# Altium schematic sheet Baseband.SchDoc(Baseband)
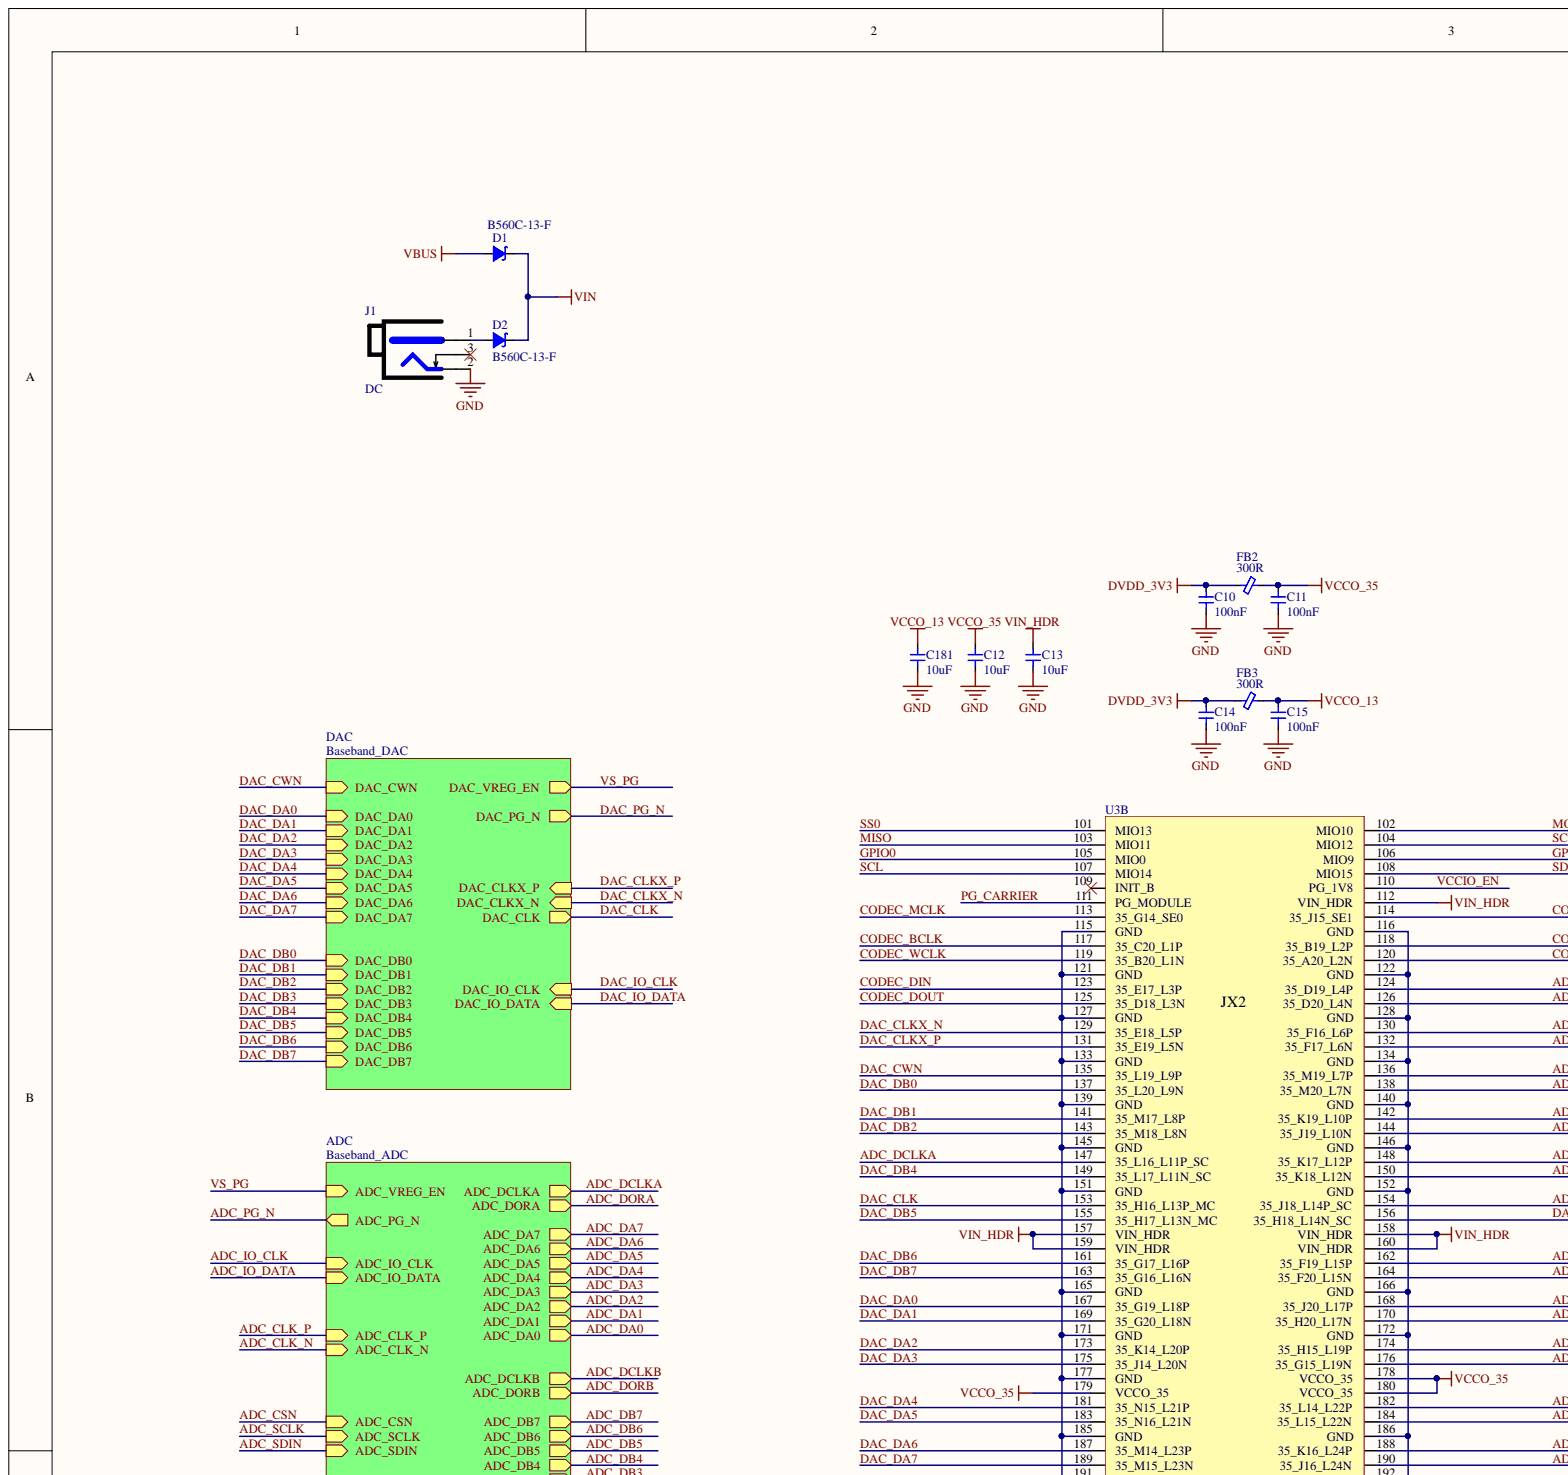
[diagram p0: Baseband.SchDoc, top left]
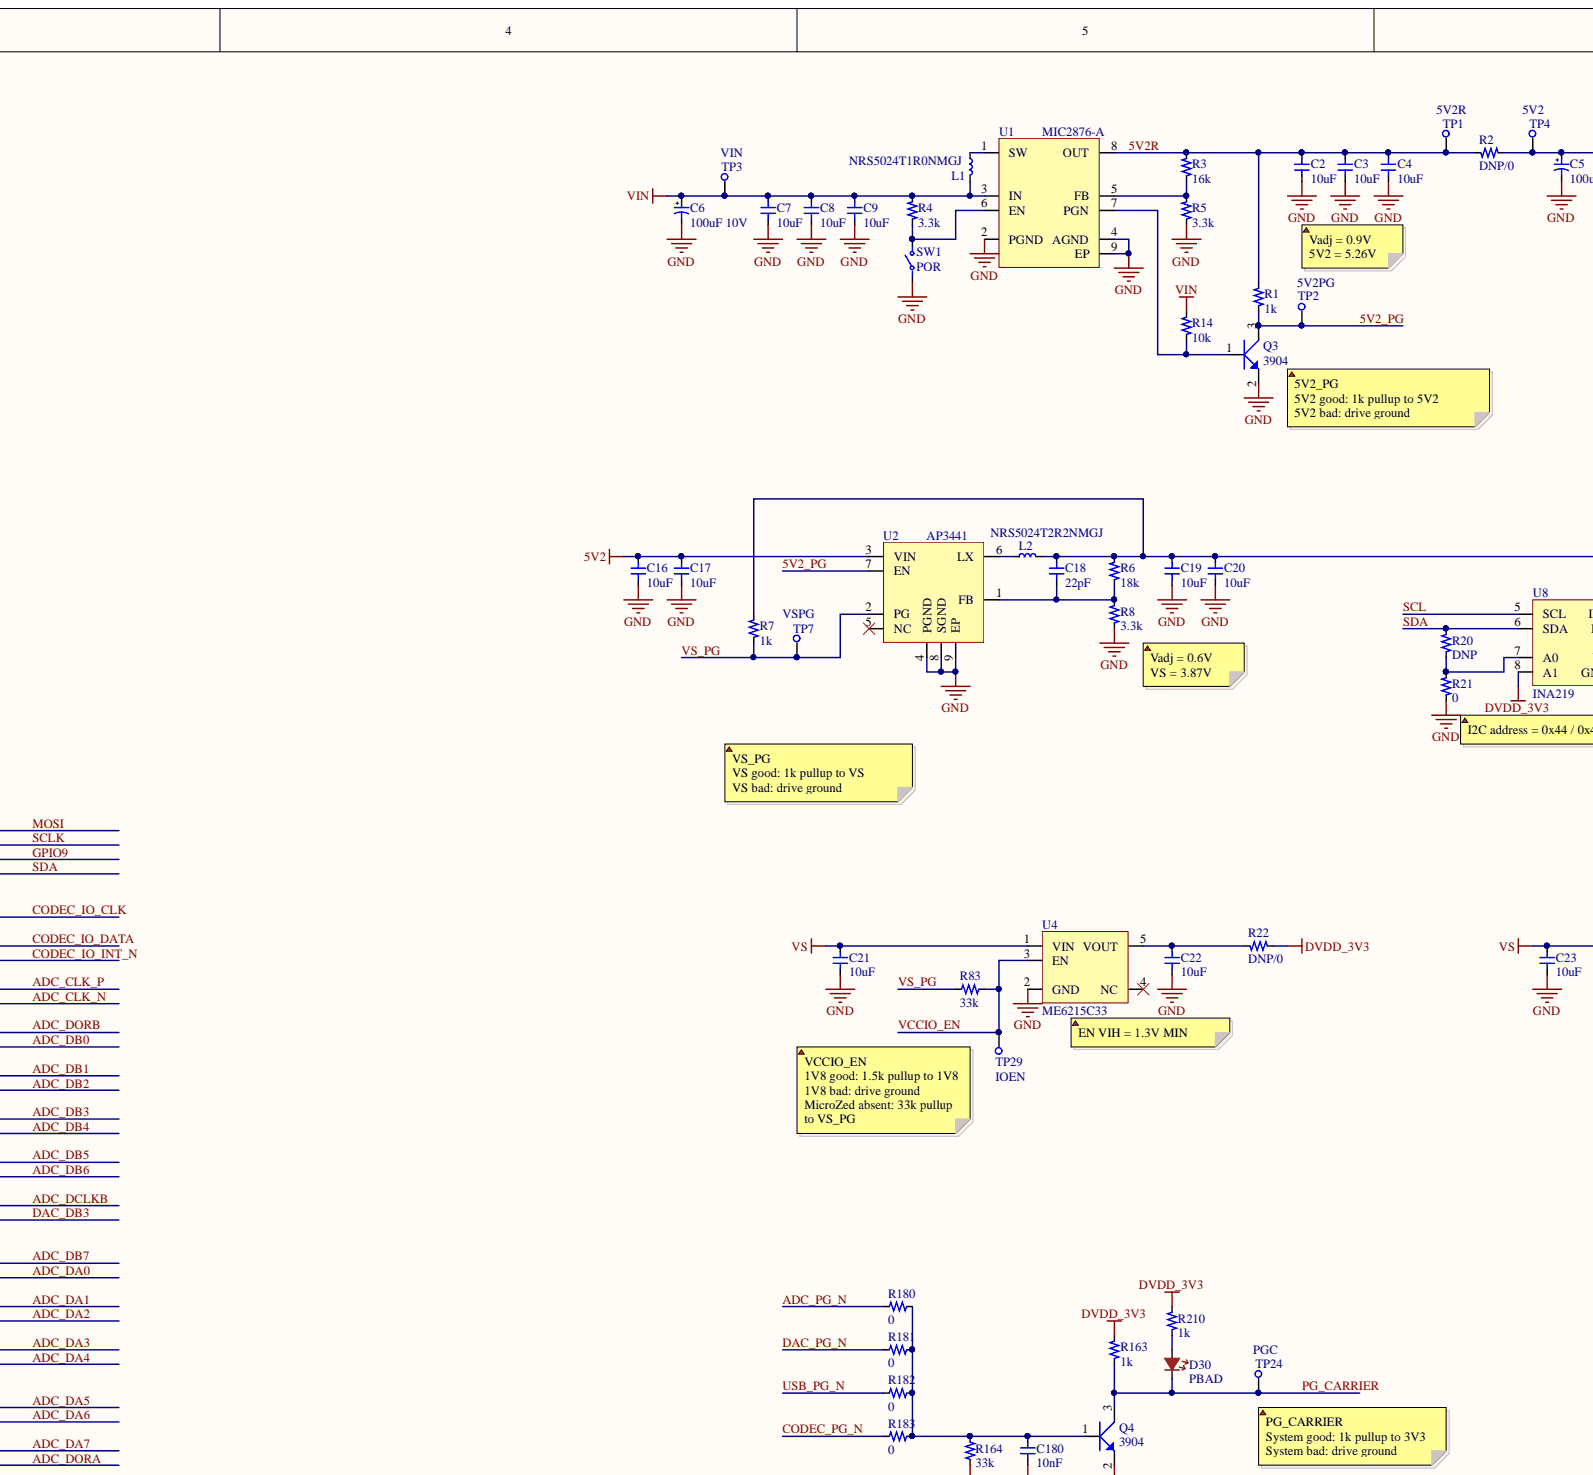
[diagram p1: Baseband.SchDoc, top centre]
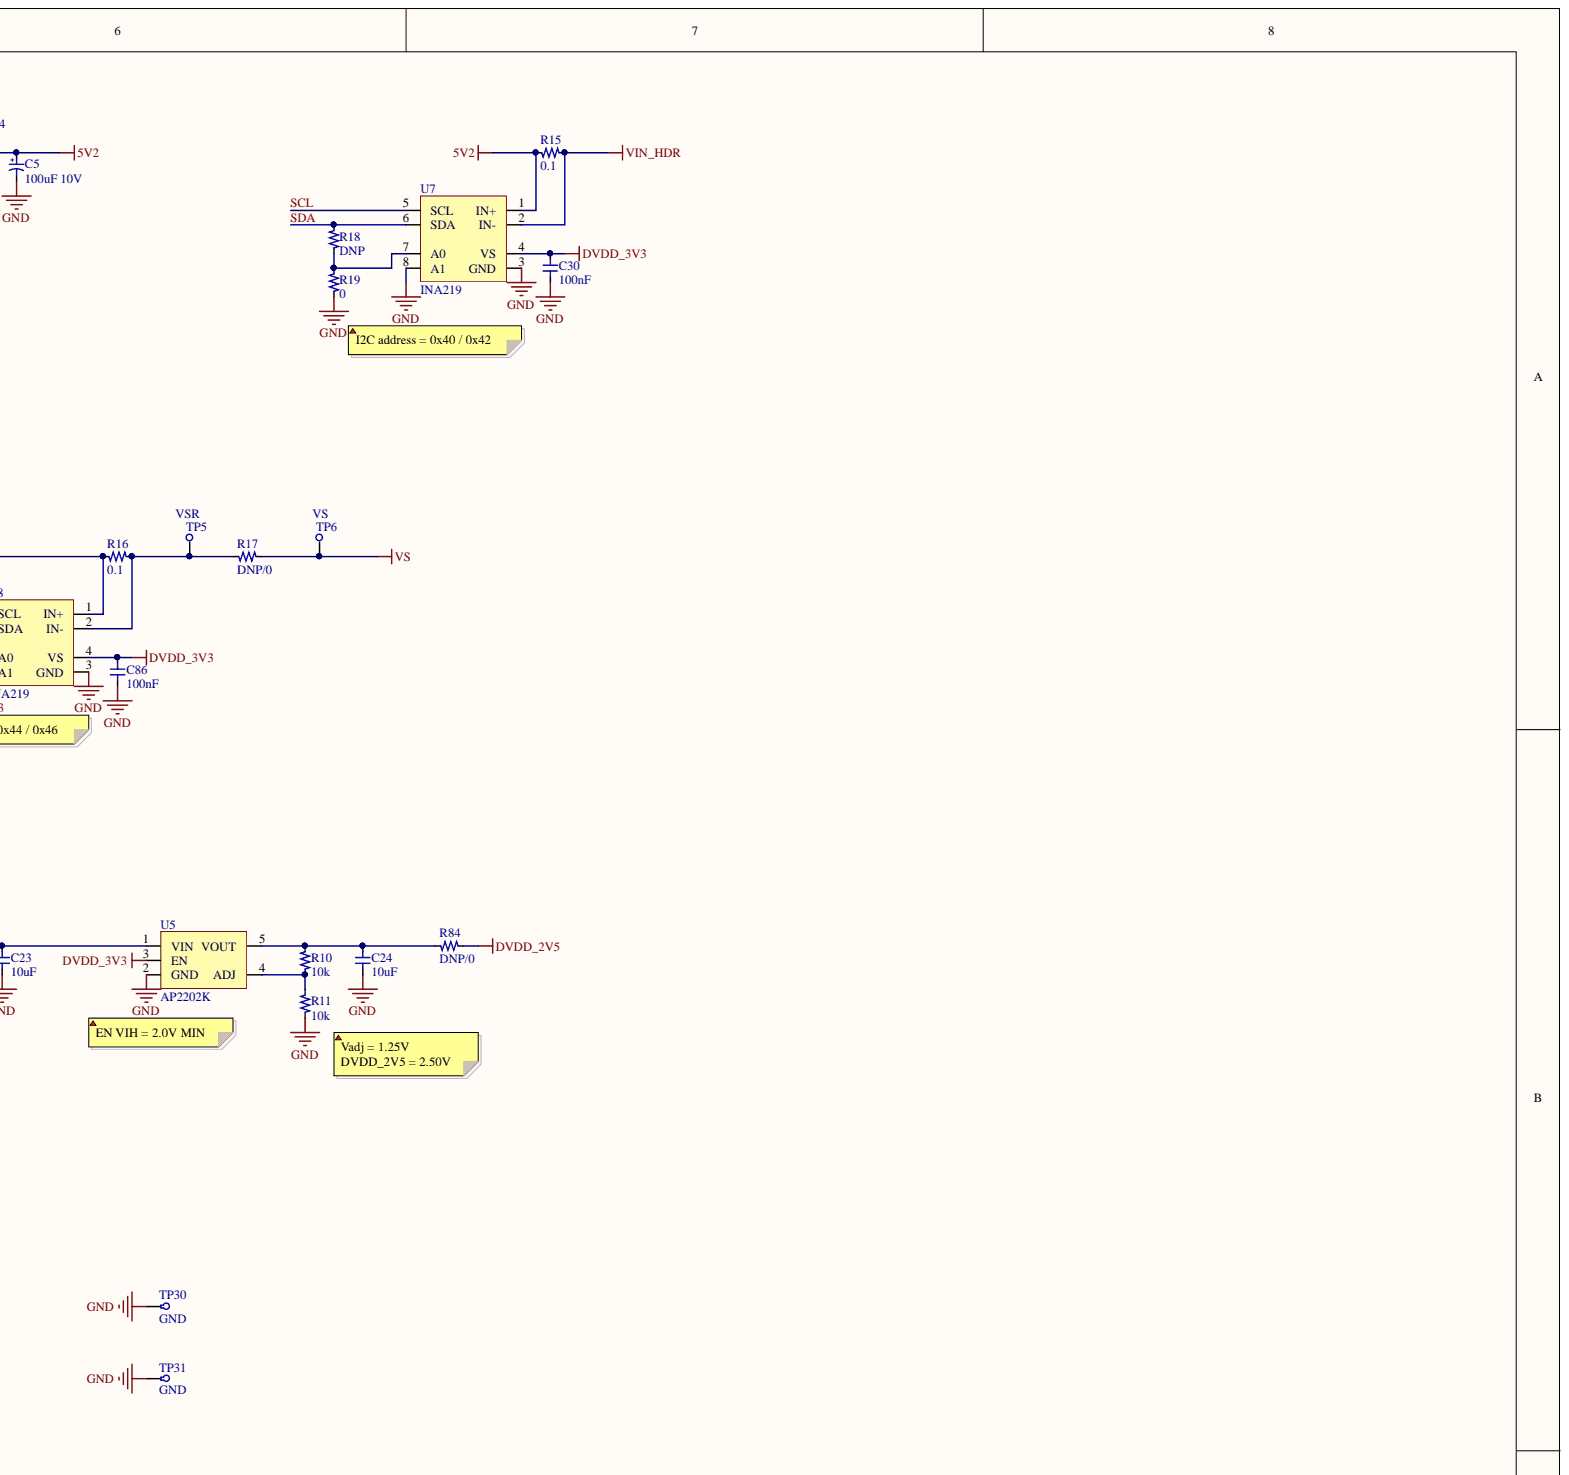
[diagram p2: Baseband.SchDoc, top right]
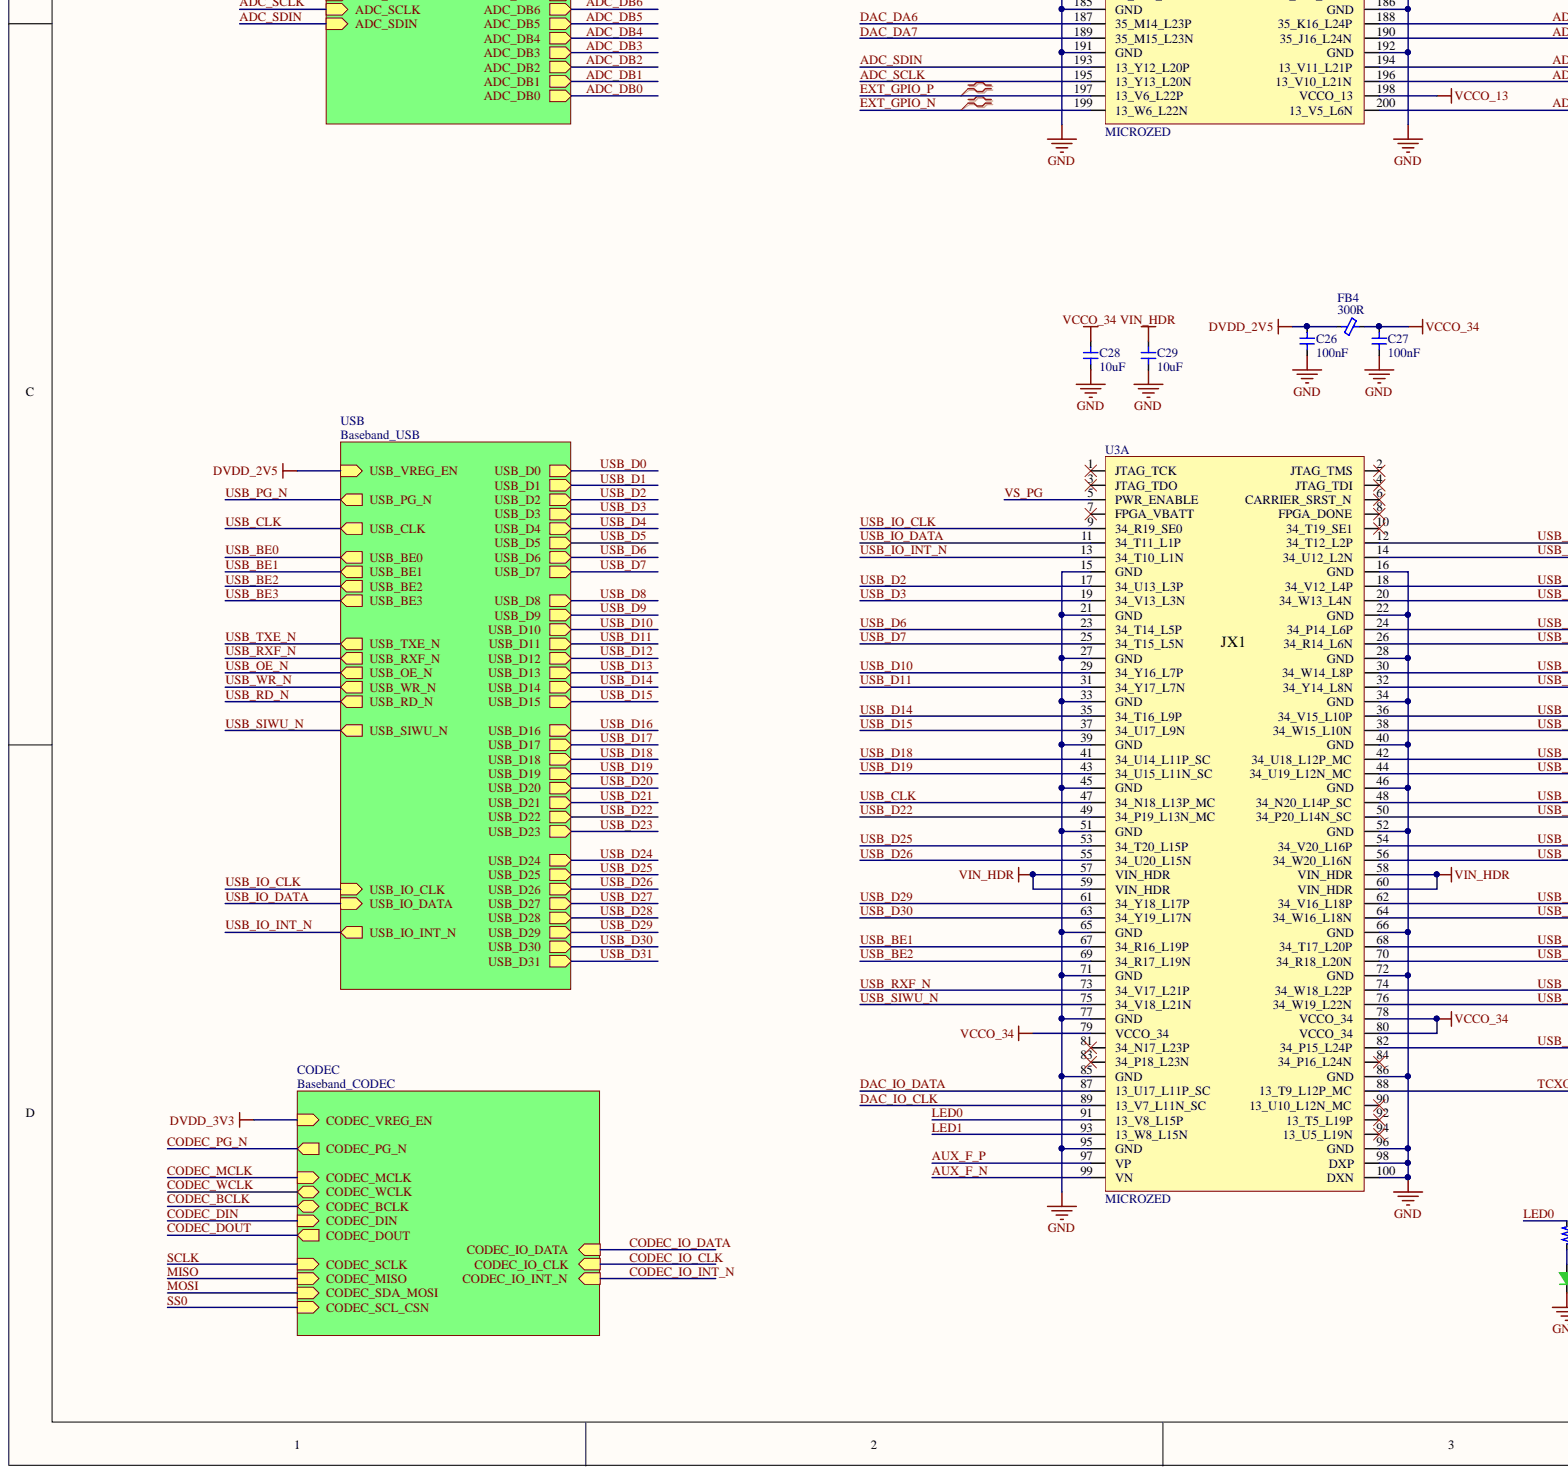
[diagram p3: Baseband.SchDoc, bottom left]
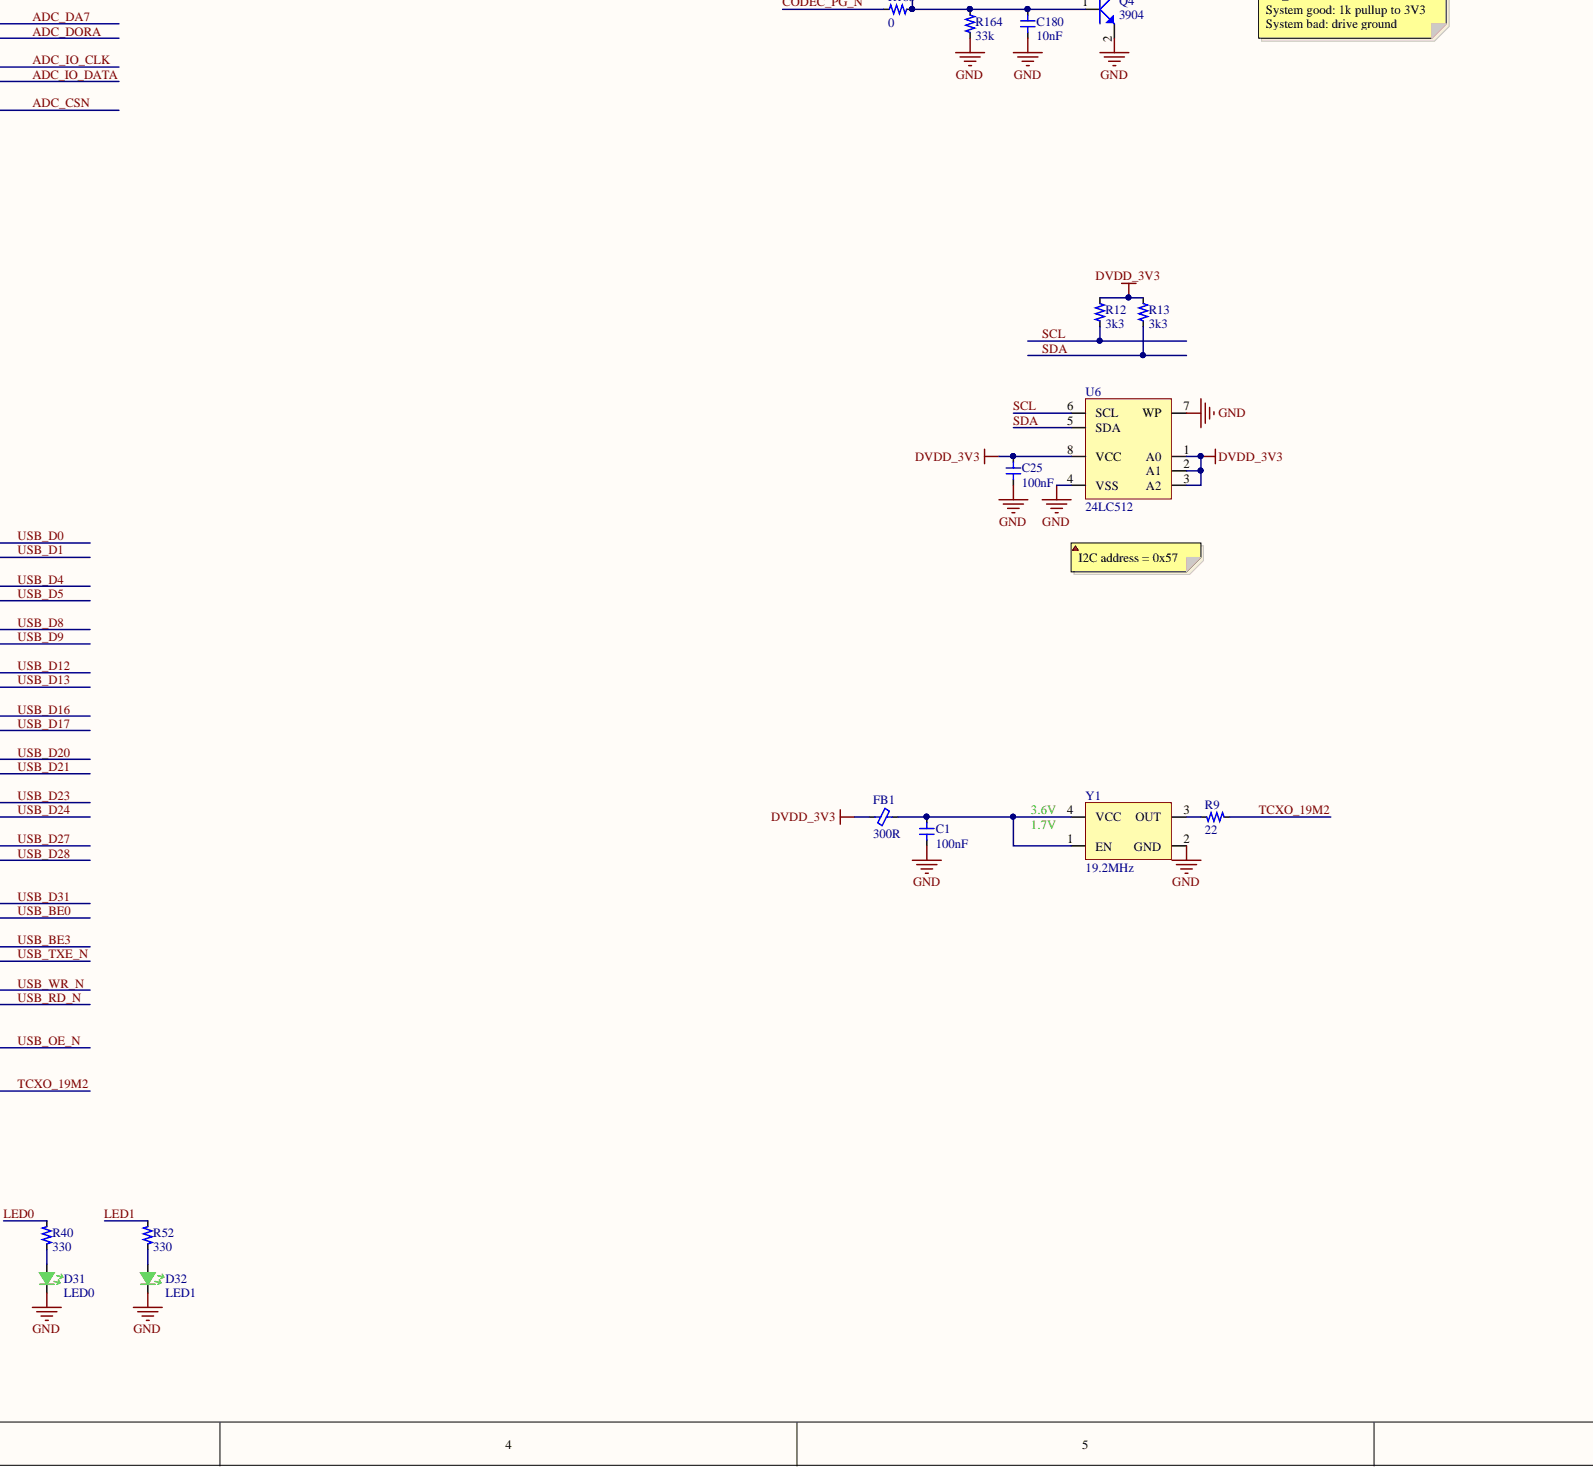
[diagram p4: Baseband.SchDoc, bottom centre]
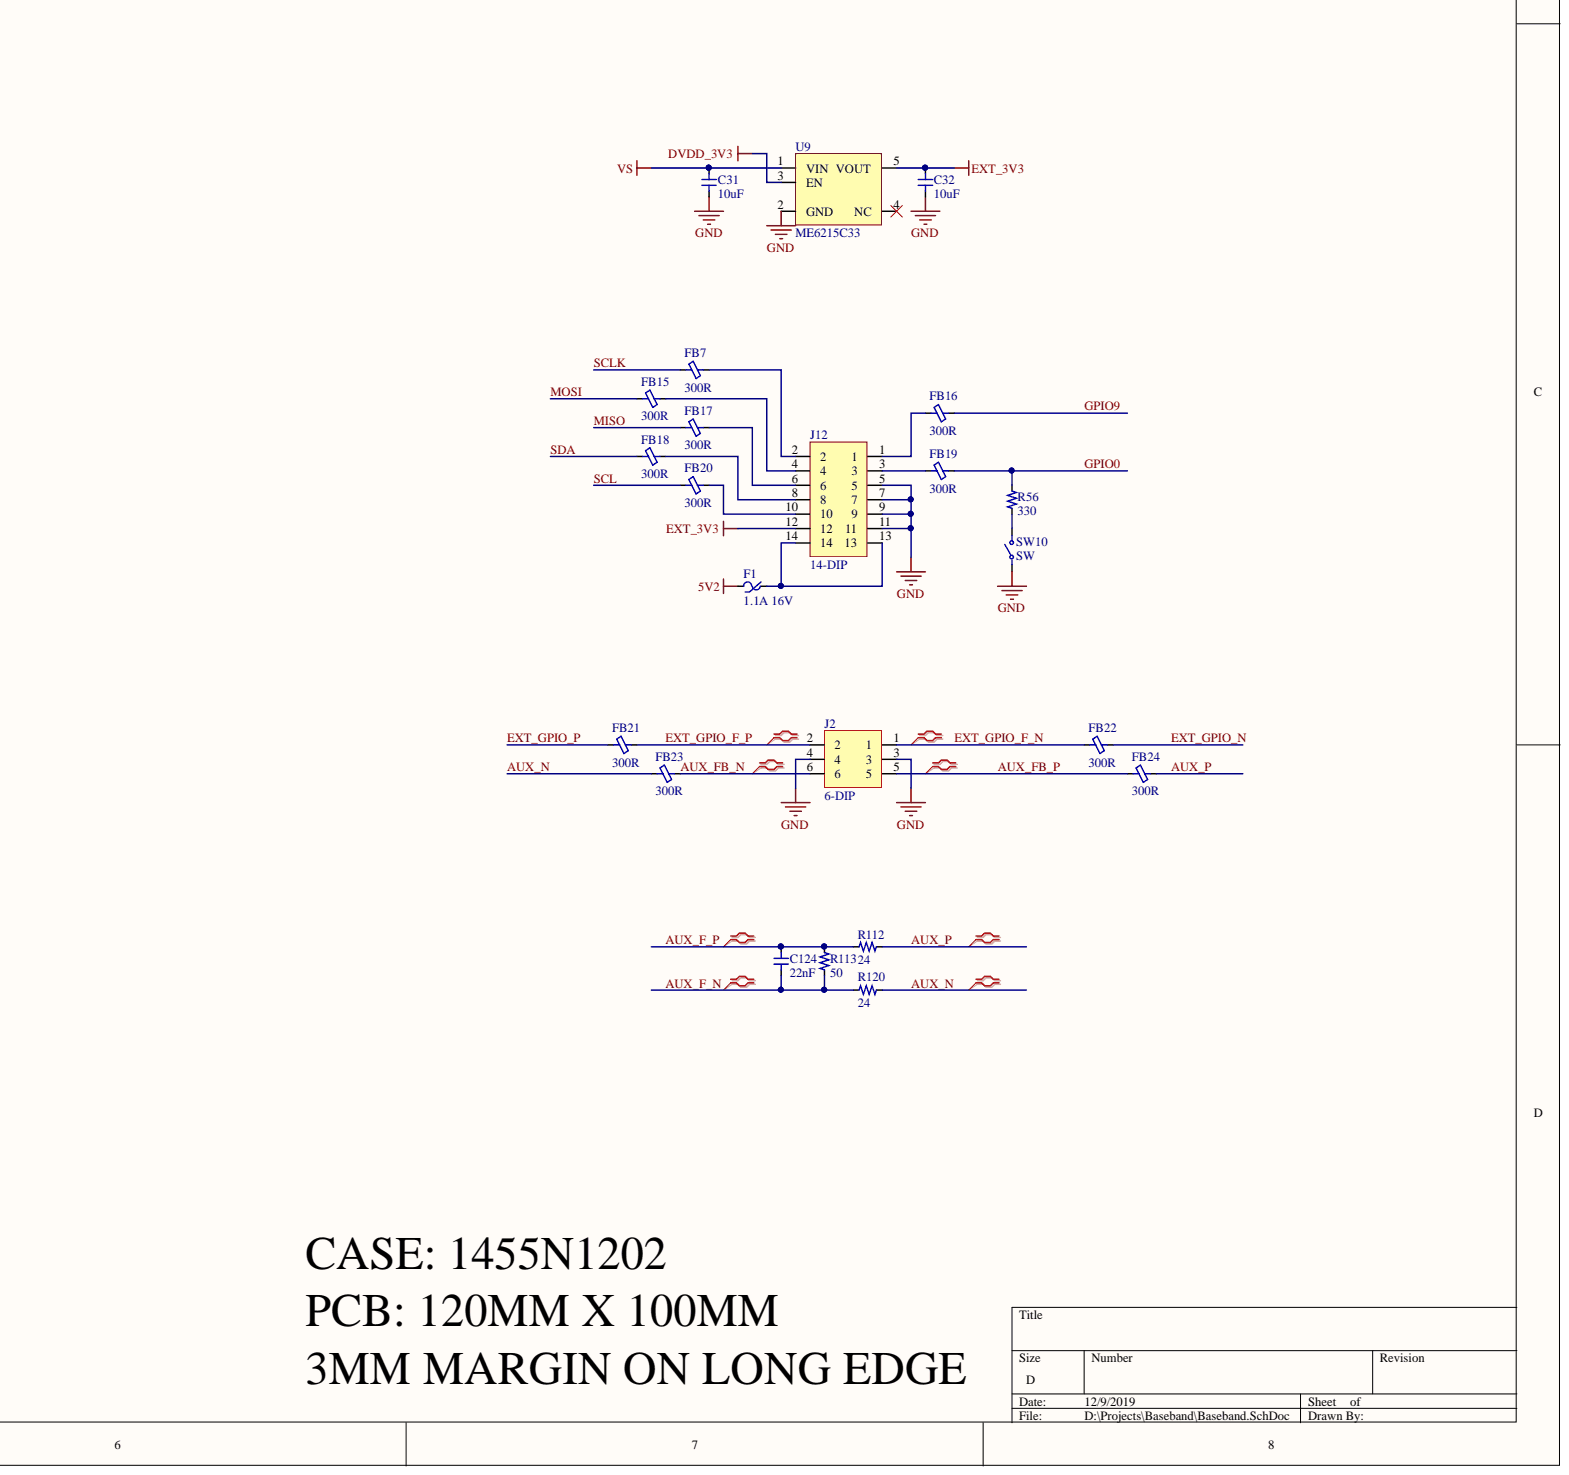
[diagram p5: Baseband.SchDoc, bottom right]

## Parts
C1  100nF  [2-0603C]
C2  10uF  [2-1206C]
C3  10uF  [2-1206C]
C4  10uF  [2-1206C]
C5  100uF 10V  [2-CAP_AL_5D6H]
C6  100uF 10V  [2-CAP_AL_5D6H]
C7  10uF  [2-1206C]
C8  10uF  [2-1206C]
C9  10uF  [2-1206C]
C10  100nF  [2-0603C]
C11  100nF  [2-0603C]
C12  10uF  [2-1206C]
C13  10uF  [2-1206C]
C14  100nF  [2-0603C]
C15  100nF  [2-0603C]
C16  10uF  [2-1206C]
C17  10uF  [2-1206C]
C18  22pF  [2-0603C]
C19  10uF  [2-1206C]
C20  10uF  [2-1206C]
C21  10uF  [2-1206C]
C22  10uF  [2-1206C]
C23  10uF  [2-1206C]
C24  10uF  [2-1206C]
C25  100nF  [2-0603C]
C26  100nF  [2-0603C]
C27  100nF  [2-0603C]
C28  10uF  [2-1206C]
C29  10uF  [2-1206C]
C30  100nF  [2-0603C]
C31  10uF  [2-1206C]
C32  10uF  [2-1206C]
C86  100nF  [2-0603C]
C124  22nF  [2-0603C]
C180  10nF  [2-0603C]
C181  10uF  [2-1206C]
D1  B560C-13-F  [2-SMC]
D2  B560C-13-F  [2-SMC]
D30  PBAD  [2-0603_LED_RED]
D31  LED0  [2-0603_LED_GREEN]
D32  LED1  [2-0603_LED_GREEN]
F1  1.1A 16V  [1812L110/16DR]
FB1  300R  [2-0805L]
FB2  300R  [2-0805L]
FB3  300R  [2-0805L]
FB4  300R  [2-0805L]
FB7  300R  [2-0805L]
FB15  300R  [2-0805L]
FB16  300R  [2-0805L]
FB17  300R  [2-0805L]
FB18  300R  [2-0805L]
FB19  300R  [2-0805L]
FB20  300R  [2-0805L]
FB21  300R  [2-0805L]
FB22  300R  [2-0805L]
FB23  300R  [2-0805L]
FB24  300R  [2-0805L]
J1  DC  [3-DC]
J2  6-DIP  [6-DIP_HDR_STR_TH]
J12  14-DIP  [14-DIP_HDR_STR_TH]
L1  NRS5024T1R0NMGJ  [2-5050L]
L2  NRS5024T2R2NMGJ  [2-5050L]
Q3  3904  [3-SOT23]
Q4  3904  [3-SOT23]
R1  1k  [2-0603R]
R2  DNP/0  [2-0603R]
R3  16k  [2-0603R]
R4  3.3k  [2-0603R]
R5  3.3k  [2-0603R]
R6  18k  [2-0603R]
R7  1k  [2-0603R]
R8  3.3k  [2-0603R]
R9  22  [2-0603R]
R10  10k  [2-0603R]
R11  10k  [2-0603R]
R12  3k3  [2-0603R]
R13  3k3  [2-0603R]
R14  10k  [2-0603R]
R15  0.1  [2-0603R]
R16  0.1  [2-0603R]
R17  DNP/0  [2-0603R]
R18  DNP  [2-0603R]
R19  0  [2-0603R]
R20  DNP  [2-0603R]
R21  0  [2-0603R]
R22  DNP/0  [2-0603R]
R40  330  [2-0603R]
R52  330  [2-0603R]
R56  330  [2-0603R]
R83  33k  [2-0603R]
R84  DNP/0  [2-0603R]
R112  24  [2-0603R]
R113  50  [2-0603R]
R120  24  [2-0603R]
R163  1k  [2-0603R]
R164  33k  [2-0603R]
R180  0  [2-0603R]
R181  0  [2-0603R]
R182  0  [2-0603R]
R183  0  [2-0603R]
R210  1k  [2-0603R]
SW1  POR  [2-TACT_6W3D4H]
SW10  SW  [2-TACT_6W3D4H]
TP1  5V2R  [1-TP_1.5MM]
TP2  5V2PG  [1-TP_1.5MM]
TP3  VIN  [1-TP_1.5MM]
TP4  5V2  [1-TP_1.5MM]
TP5  VSR  [1-TP_1.5MM]
TP6  VS  [1-TP_1.5MM]
TP7  VSPG  [1-TP_1.5MM]
TP24  PGC  [1-TP_1.5MM]
TP29  IOEN  [1-TP_1.5MM]
TP30  GND  [1-TPLOOP]
TP31  GND  [1-TPLOOP]
U1  MIC2876-A  [8-DFN_2X2]
U2  AP3441  [8-DFN_2X2]
U3  MICROZED  [MICROZED_2MOUNT]  (parts A,B)
U4  ME6215C33  [5-SOT23]
U5  AP2202K  [5-SOT23]
U6  24LC512  [8-TSSOP]
U7  INA219  [8-SOIC_N]
U8  INA219  [8-SOIC_N]
U9  ME6215C33  [5-SOT23]
Y1  19.2MHz  [4-XTAL_2016]

## Nets
5V2: C5.1 C16.1 C17.1 F1.1 R2.2 R15.2 TP4.1 U2.3(VIN) U7.1(IN+)
DVDD_2V5: C26.1 FB4.2 R84.2
DVDD_3V3: C10.1 C14.1 C25.1 C30.1 C86.1 FB1.2 FB2.2 FB3.2 R12.2 R13.2 R22.2 R163.1 R210.1 U5.3(EN) U6.1(A0) U6.2(A1) U6.3(A2) U6.8(VCC) U7.4(VS) U8.4(VS) U8.8(A1) U9.3(EN)
EXT_3V3: C32.1 J12.12 U9.5(VOUT)
GND: C1.2 C2.2 C3.2 C4.2 C5.2 C6.2 C7.2 C8.2 C9.2 C10.2 C11.2 C12.2 C13.2 C14.2 C15.2 C16.2 C17.2 C19.2 C20.2 C21.2 C22.2 C23.2 C24.2 C25.2 C26.2 C27.2 C28.2 C29.2 C30.2 C31.2 C32.2 C86.2 C180.2 C181.2 D31.2 D32.2 J1.2 J2.3 J2.4 J12.5 J12.7 J12.9 J12.11 Q3.2 Q4.2 R5.2 R8.2 R11.2 R19.1 R21.1 R164.2 SW1.1 SW10.1 TP30.1 TP31.1 U1.2(PGND) U1.4(AGND) U1.9(EP) U2.4(PGND) U2.8(SGND) U2.9(EP) U3.15(GND) U3.16(GND) U3.21(GND) U3.22(GND) U3.27(GND) U3.28(GND) U3.33(GND) U3.34(GND) U3.39(GND) U3.40(GND) U3.45(GND) U3.46(GND) U3.51(GND) U3.52(GND) U3.65(GND) U3.66(GND) U3.71(GND) U3.72(GND) U3.77(GND) U3.85(GND) U3.86(GND) U3.95(GND) U3.96(GND) U3.98(DXP) U3.100(DXN) U3.115(GND) U3.116(GND) U3.121(GND) U3.122(GND) U3.127(GND) U3.128(GND) U3.133(GND) U3.134(GND) U3.139(GND) U3.140(GND) U3.145(GND) U3.146(GND) U3.151(GND) U3.152(GND) U3.165(GND) U3.166(GND) U3.171(GND) U3.172(GND) U3.177(GND) U3.185(GND) U3.186(GND) U3.191(GND) U3.192(GND) U4.2(GND) U5.2(GND) U6.4(VSS) U6.7(WP) U7.3(GND) U7.8(A1) U8.3(GND) U9.2(GND) Y1.2(GND)
VBUS: D1.1
VCCO_13: C15.1 C181.1 FB3.1 U3.198(VCCO_13)
VCCO_34: C27.1 C28.1 FB4.1 U3.78(VCCO_34) U3.79(VCCO_34) U3.80(VCCO_34)
VCCO_35: C11.1 C12.1 FB2.1 U3.178(VCCO_35) U3.179(VCCO_35) U3.180(VCCO_35)
VIN: C6.1 C7.1 C8.1 C9.1 D1.2 D2.2 L1.2 R4.1 R14.1 TP3.1 U1.3(IN)
VIN_HDR: C13.1 C29.1 R15.1 U3.57(VIN_HDR) U3.58(VIN_HDR) U3.59(VIN_HDR) U3.60(VIN_HDR) U3.112(VIN_HDR) U3.157(VIN_HDR) U3.158(VIN_HDR) U3.159(VIN_HDR) U3.160(VIN_HDR) U7.2(IN-)
VS: C21.1 C23.1 C31.1 R17.2 TP6.1 U4.1(VIN) U5.1(VIN) U9.1(VIN)
5V2_PG: Q3.3 R1.2 TP2.1 U2.7(EN)
5V2R: C2.1 C3.1 C4.1 R1.1 R2.1 R3.1 TP1.1 U1.8(OUT)
ADC_CLK_N: U3.126(35_D20_L4N)
ADC_CLK_P: U3.124(35_D19_L4P)
ADC_CSN: U3.200(13_V5_L6N)
ADC_DA0: U3.164(35_F20_L15N)
ADC_DA1: U3.168(35_J20_L17P)
ADC_DA2: U3.170(35_H20_L17N)
ADC_DA3: U3.174(35_H15_L19P)
ADC_DA4: U3.176(35_G15_L19N)
ADC_DA5: U3.182(35_L14_L22P)
ADC_DA6: U3.184(35_L15_L22N)
ADC_DA7: U3.188(35_K16_L24P)
ADC_DB0: U3.132(35_F17_L6N)
ADC_DB1: U3.136(35_M19_L7P)
ADC_DB2: U3.138(35_M20_L7N)
ADC_DB3: U3.142(35_K19_L10P)
ADC_DB4: U3.144(35_J19_L10N)
ADC_DB5: U3.148(35_K17_L12P)
ADC_DB6: U3.150(35_K18_L12N)
ADC_DB7: U3.162(35_F19_L15P)
ADC_DCLKA: U3.147(35_L16_L11P_SC)
ADC_DCLKB: U3.154(35_J18_L14P_SC)
ADC_DORA: U3.190(35_J16_L24N)
ADC_DORB: U3.130(35_F16_L6P)
ADC_IO_CLK: U3.194(13_V11_L21P)
ADC_IO_DATA: U3.196(13_V10_L21N)
ADC_PG_N: R180.1
ADC_SCLK: U3.195(13_Y13_L20N)
ADC_SDIN: U3.193(13_Y12_L20P)
AUX_F_N: C124.2 R113.2 R120.2 U3.99(VN)
AUX_F_P: C124.1 R112.2 R113.1 U3.97(VP)
AUX_FB_N: FB23.2 J2.6
AUX_FB_P: FB24.1 J2.5
AUX_N: FB23.1 R120.1
AUX_P: FB24.2 R112.1
CODEC_BCLK: U3.117(35_C20_L1P)
CODEC_DIN: U3.123(35_E17_L3P)
CODEC_DOUT: U3.125(35_D18_L3N)
CODEC_IO_CLK: U3.114(35_J15_SE1)
CODEC_IO_DATA: U3.118(35_B19_L2P)
CODEC_IO_INT_N: U3.120(35_A20_L2N)
CODEC_MCLK: U3.113(35_G14_SE0)
CODEC_PG_N: R183.1
CODEC_WCLK: U3.119(35_B20_L1N)
DAC_CLK: U3.153(35_H16_L13P_MC)
DAC_CLKX_N: U3.129(35_E18_L5P)
DAC_CLKX_P: U3.131(35_E19_L5N)
DAC_CWN: U3.135(35_L19_L9P)
DAC_DA0: U3.167(35_G19_L18P)
DAC_DA1: U3.169(35_G20_L18N)
DAC_DA2: U3.173(35_K14_L20P)
DAC_DA3: U3.175(35_J14_L20N)
DAC_DA4: U3.181(35_N15_L21P)
DAC_DA5: U3.183(35_N16_L21N)
DAC_DA6: U3.187(35_M14_L23P)
DAC_DA7: U3.189(35_M15_L23N)
DAC_DB0: U3.137(35_L20_L9N)
DAC_DB1: U3.141(35_M17_L8P)
DAC_DB2: U3.143(35_M18_L8N)
DAC_DB3: U3.156(35_H18_L14N_SC)
DAC_DB4: U3.149(35_L17_L11N_SC)
DAC_DB5: U3.155(35_H17_L13N_MC)
DAC_DB6: U3.161(35_G17_L16P)
DAC_DB7: U3.163(35_G16_L16N)
DAC_IO_CLK: U3.89(13_V7_L11N_SC)
DAC_IO_DATA: U3.87(13_U17_L11P_SC)
DAC_PG_N: R181.1
EXT_GPIO_F_N: FB22.1 J2.1
EXT_GPIO_F_P: FB21.2 J2.2
EXT_GPIO_N: FB22.2 U3.199(13_W6_L22N)
EXT_GPIO_P: FB21.1 U3.197(13_V6_L22P)
GPIO0: FB19.2 R56.1 U3.105(MIO0)
GPIO9: FB16.2 U3.106(MIO9)
LED0: R40.1 U3.91(13_V8_L15P)
LED1: R52.1 U3.93(13_W8_L15N)
MISO: FB17.1 U3.103(MIO11)
MOSI: FB15.1 U3.102(MIO10)
PG_CARRIER: D30.2 Q4.3 R163.2 TP24.1 U3.111(PG_MODULE)
SCL: FB20.1 R12.1 U3.107(MIO14) U6.6(SCL) U7.5(SCL) U8.5(SCL)
SCLK: FB7.1 U3.104(MIO12)
SDA: FB18.1 R13.1 R18.1 R20.1 U3.108(MIO15) U6.5(SDA) U7.6(SDA) U8.6(SDA)
SS0: U3.101(MIO13)
TCXO_19M2: R9.2 U3.88(13_T9_L12P_MC)
USB_BE0: U3.64(34_W16_L18N)
USB_BE1: U3.67(34_R16_L19P)
USB_BE2: U3.69(34_R17_L19N)
USB_BE3: U3.68(34_T17_L20P)
USB_CLK: U3.47(34_N18_L13P_MC)
USB_D0: U3.12(34_T12_L2P)
USB_D1: U3.14(34_U12_L2N)
USB_D2: U3.17(34_U13_L3P)
USB_D3: U3.19(34_V13_L3N)
USB_D4: U3.18(34_V12_L4P)
USB_D5: U3.20(34_W13_L4N)
USB_D6: U3.23(34_T14_L5P)
USB_D7: U3.25(34_T15_L5N)
USB_D8: U3.24(34_P14_L6P)
USB_D9: U3.26(34_R14_L6N)
USB_D10: U3.29(34_Y16_L7P)
USB_D11: U3.31(34_Y17_L7N)
USB_D12: U3.30(34_W14_L8P)
USB_D13: U3.32(34_Y14_L8N)
USB_D14: U3.35(34_T16_L9P)
USB_D15: U3.37(34_U17_L9N)
USB_D16: U3.36(34_V15_L10P)
USB_D17: U3.38(34_W15_L10N)
USB_D18: U3.41(34_U14_L11P_SC)
USB_D19: U3.43(34_U15_L11N_SC)
USB_D20: U3.42(34_U18_L12P_MC)
USB_D21: U3.44(34_U19_L12N_MC)
USB_D22: U3.49(34_P19_L13N_MC)
USB_D23: U3.48(34_N20_L14P_SC)
USB_D24: U3.50(34_P20_L14N_SC)
USB_D25: U3.53(34_T20_L15P)
USB_D26: U3.55(34_U20_L15N)
USB_D27: U3.54(34_V20_L16P)
USB_D28: U3.56(34_W20_L16N)
USB_D29: U3.61(34_Y18_L17P)
USB_D30: U3.63(34_Y19_L17N)
USB_D31: U3.62(34_V16_L18P)
USB_IO_CLK: U3.9(34_R19_SE0)
USB_IO_DATA: U3.11(34_T11_L1P)
USB_IO_INT_N: U3.13(34_T10_L1N)
USB_OE_N: U3.82(34_P15_L24P)
USB_PG_N: R182.1
USB_RD_N: U3.76(34_W19_L22N)
USB_RXF_N: U3.73(34_V17_L21P)
USB_SIWU_N: U3.75(34_V18_L21N)
USB_TXE_N: U3.70(34_R18_L20N)
USB_WR_N: U3.74(34_W18_L22P)
VCCIO_EN: R83.2 TP29.1 U3.110(PG_1V8) U4.3(EN)
VS_PG: R7.2 R83.1 TP7.1 U2.2(PG) U3.5(PWR_ENABLE)
NetC1_1: C1.1 FB1.1 Y1.1(EN) Y1.4(VCC)
NetC18_1: C18.1 C19.1 C20.1 L2.2 R6.1 R7.1 R16.2 U8.1(IN+)
NetC18_2: C18.2 R6.2 R8.1 U2.1(FB)
NetC22_1: C22.1 R22.1 U4.5(VOUT)
NetC24_1: C24.1 R10.1 R84.1 U5.5(VOUT)
NetC180_1: C180.1 Q4.1 R164.1 R180.2 R181.2 R182.2 R183.2
NetD2_1: D2.1 J1.1
NetD30_1: D30.1 R210.2
NetD31_1: D31.1 R40.2
NetD32_1: D32.1 R52.2
NetF1_2: F1.2 J12.13 J12.14
NetFB7_2: FB7.2 J12.2
NetFB15_2: FB15.2 J12.4
NetFB16_1: FB16.1 J12.1
NetFB17_2: FB17.2 J12.6
NetFB18_2: FB18.2 J12.8
NetFB19_1: FB19.1 J12.3
NetFB20_2: FB20.2 J12.10
NetJ1_3: J1.3
NetL1_1: L1.1 U1.1(SW)
NetL2_1: L2.1 U2.6(LX)
NetQ3_1: Q3.1 R14.2 U1.7(PGN)
NetR3_2: R3.2 R5.1 U1.5(FB)
NetR4_2: R4.2 SW1.2 U1.6(EN)
NetR9_1: R9.1 Y1.3(OUT)
NetR10_2: R10.2 R11.1 U5.4(ADJ)
NetR16_1: R16.1 R17.1 TP5.1 U8.2(IN-)
NetR18_2: R18.2 R19.2 U7.7(A0)
NetR20_2: R20.2 R21.2 U8.7(A0)
NetR56_2: R56.2 SW10.2
NetU2_5: U2.5(NC)
NetU3_1: U3.1(JTAG_TCK)
NetU3_2: U3.2(JTAG_TMS)
NetU3_3: U3.3(JTAG_TDO)
NetU3_4: U3.4(JTAG_TDI)
NetU3_6: U3.6(CARRIER_SRST_N)
NetU3_7: U3.7(FPGA_VBATT)
NetU3_8: U3.8(FPGA_DONE)
NetU3_10: U3.10(34_T19_SE1)
NetU3_81: U3.81(34_N17_L23P)
NetU3_83: U3.83(34_P18_L23N)
NetU3_84: U3.84(34_P16_L24N)
NetU3_90: U3.90(13_U10_L12N_MC)
NetU3_92: U3.92(13_T5_L19P)
NetU3_94: U3.94(13_U5_L19N)
NetU3_109: U3.109(INIT_B)
NetU4_4: U4.4(NC)
NetU9_4: U9.4(NC)

## Library data of the parts
C1-C4 C7-C32 C86 C124 C180 C181 = C: CAP; Component Kind: Standard; Library Name: Generic.SchLib
C5 C6 = CPOL: CAP POLARIZED; Component Kind: Standard; Library Name: Generic.SchLib
D1 D2 = D SCHOTTKY: DIODE SCHOTTKY; Component Kind: Standard; Library Name: Generic.SchLib
D30 = LED RED: Component Kind: Standard; Description: LED RED; Library Name: Generic.SchLib
D31 D32 = LED GREEN: Component Kind: Standard; Description: LED GREEN; Library Name: Generic.SchLib
F1 = 1812L110/16DR: PTC RESET FUSE 16V 1.1A; Component Kind: Standard; Library Name: Specific.SchLib
FB1-FB4 FB7 FB15-FB24 = FB: FE BEAD; Component Kind: Standard; Library Name: Generic.SchLib
J1 = 3-DC: Connector DC Power; Component Kind: Standard; Library Name: Connectors.SchLib
L1 L2 = L: IND; Component Kind: Standard; Library Name: Generic.SchLib
Q3 Q4 = MMBT3904: TRANS NPN 40V 0.2A; Component Kind: Standard; Library Name: Specific.SchLib
R1-R22 R40 R52 R56 R83 R84 R112 R113 R120 R163 R164 R180-R183 R210 = R: RES; Component Kind: Standard; Library Name: Generic.SchLib
SW1 SW10 = SPST: SWITCH SPST; Component Kind: Standard; Library Name: Generic.SchLib
TP1-TP7 TP24 TP29 = TP: TEST POINT; Component Kind: Standard; Library Name: Generic.SchLib
TP30 TP31 = TP_LOOP: TEST LOOP; Component Kind: Standard; Library Name: Generic.SchLib
U1 = MIC2876-A: IC REG BOOST ADJ 3.8A; Component Kind: Standard; Library Name: Specific.SchLib
U2 = AP3441: IC REG BUCK ADJ 3A; Component Kind: Standard; Library Name: Specific.SchLib
U4 U9 = ME6215C33: IC REG LDO 3.3V 300mA; Component Kind: Standard; Library Name: Specific.SchLib
U5 = AP2202K: IC REG LDO ADJ 150MA; Component Kind: Standard; Library Name: Specific.SchLib
U6 = 24LC512: IC EEPROM 512K I2C 400KHZ; Component Kind: Standard; Library Name: Specific.SchLib
U7 U8 = INA219: IC CURRENT MONITOR 1%; Component Kind: Standard; Library Name: Specific.SchLib
Y1 = TG-5035CJ-13N 19.2000M3: XTAL OSC TCXO 19.2000MHZ SMD; Component Kind: Standard; Library Name: Specific.SchLib
J2 = 6-DIP: Component Kind: Standard; Library Name: Connectors.SchLib
J12 = 14-DIP: Component Kind: Standard; Library Name: Connectors.SchLib
U3 = MICROZED: Component Kind: Standard; Library Name: Specific.SchLib
